# Altium schematic sheet 04 PX4-Connect.SchDoc("04 PX4-Connect")
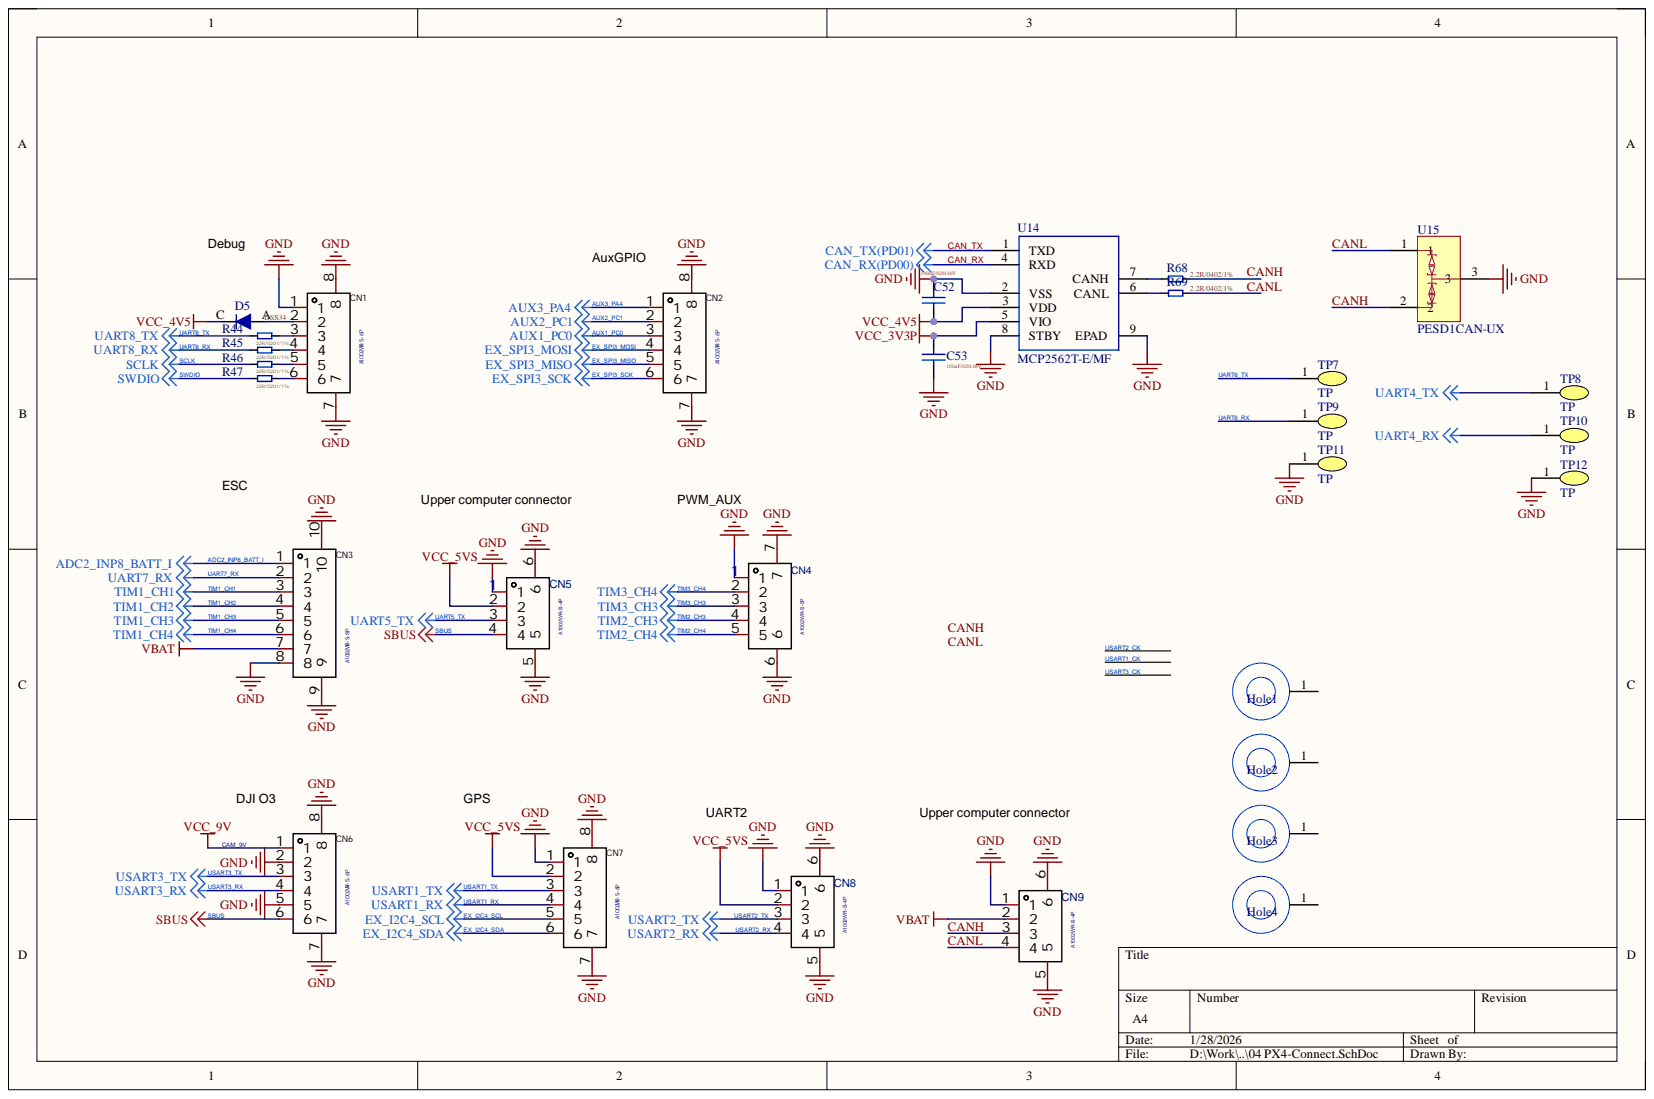
[diagram p0: 04 PX4-Connect.SchDoc]

## Parts
C52  100nF/0201/16V  [C0201]
C53  100nF/0201/16V  [C0201]
CN1  A1002WR-S-6P  [CONN-SMD_A1002WR-S-6P]
CN2  A1002WR-S-6P  [CONN-SMD_A1002WR-S-6P]
CN3  A1002WR-S-8P  [CONN-SMD_A1002WR-S-8P]
CN4  A1002WR-S-5P  [CONN-SMD_A1002WR-S-5P]
CN5  A1002WR-S-4P  [CONN-SMD_A1002WR-S-4P]
CN6  A1002WR-S-6P  [CONN-SMD_A1002WR-S-6P]
CN7  A1002WR-S-6P  [CONN-SMD_A1002WR-S-6P]
CN8  A1002WR-S-4P  [CONN-SMD_A1002WR-S-4P]
CN9  A1002WR-S-4P  [CONN-SMD_A1002WR-S-4P]
D5  DSS34  [SOD-123FL_L2.8-W1.8-LS3.7-AC]
Hole1  Hole  [HOLE_PC3P4DC3P05]
Hole2  Hole  [HOLE_PC3P4DC3P05]
Hole3  Hole  [HOLE_PC3P4DC3P05]
Hole4  Hole  [HOLE_PC3P4DC3P05]
R44  22R/0201/1%  [R0201]
R45  22R/0201/1%  [R0201]
R46  22R/0201/1%  [R0201]
R47  22R/0201/1%  [R0201]
R68  2.2R/0402/1%  [R0402]
R69  2.2R/0402/1%  [R0402]
TP7  TP  [PAD1x1.2]
TP8  TP  [PAD1x1.2]
TP9  TP  [PAD1x1.2]
TP10  TP  [PAD1x1.2]
TP11  TP  [PAD1x1.2]
TP12  TP  [PAD1x1.2]
U14  MCP2562T-E/MF  [DFN-8_EP_3X3MM-0.65]
U15  PESD1CAN-UX  [SOT-323_L2.0-W1.3-P1.30-LS2.1-BR]

## Nets
GND: C52.1 C53.1 CN1.1 CN1.7 CN1.8 CN2.7 CN2.8 CN3.8 CN3.9 CN3.10 CN4.1 CN4.6 CN4.7 CN5.1 CN5.5 CN5.6 CN6.2 CN6.5 CN6.7 CN6.8 CN7.1 CN7.7 CN7.8 CN8.1 CN8.5 CN8.6 CN9.1 CN9.5 CN9.6 TP11.1 TP12.1 U14.2(VSS) U14.8(STBY) U14.9(EPAD) U15.3
UART4_RX: TP10.1
UART4_TX: TP8.1
VBAT: CN3.7 CN9.2
VCC_3V3P: C53.2 U14.5(VIO)
VCC_4V5: C52.2 D5.C U14.3(VDD)
VCC_5VS: CN5.2 CN7.2 CN8.2
ADC2_INP8_BATT_I: CN3.1
AUX1_PC0: CN2.3
AUX2_PC1: CN2.2
AUX3_PA4: CN2.1
CAM_9V: CN6.1
CAN_RX: U14.4(RXD)
CAN_TX: U14.1(TXD)
CANH: CN9.3 R68.1 U15.2
CANL: CN9.4 R69.1 U15.1
EX_I2C4_SCL: CN7.5
EX_I2C4_SDA: CN7.6
EX_SPI3_MISO: CN2.5
EX_SPI3_MOSI: CN2.4
EX_SPI3_SCK: CN2.6
SBUS: CN5.4 CN6.6
SCLK: R46.2
SWDIO: R47.2
TIM1_CH1: CN3.3
TIM1_CH2: CN3.4
TIM1_CH3: CN3.5
TIM1_CH4: CN3.6
TIM2_CH3: CN4.4
TIM2_CH4: CN4.5
TIM3_CH3: CN4.3
TIM3_CH4: CN4.2
UART5_TX: CN5.3
UART6_RX: TP9.1
UART6_TX: TP7.1
UART7_RX: CN3.2
UART8_RX: R45.2
UART8_TX: R44.2
USART1_RX: CN7.4
USART1_TX: CN7.3
USART2_RX: CN8.4
USART2_TX: CN8.3
USART3_RX: CN6.4
USART3_TX: CN6.3
NetCN1_2: CN1.2 D5.A
NetCN1_3: CN1.3 R44.1
NetCN1_4: CN1.4 R45.1
NetCN1_5: CN1.5 R46.1
NetCN1_6: CN1.6 R47.1
NetR68_2: R68.2 U14.7(CANH)
NetR69_2: R69.2 U14.6(CANL)
USART1_CK:
USART2_CK:
USART3_CK:

Not connected: Hole1 1; Hole2 1; Hole3 1; Hole4 1

## Library data of the parts
C52 C53 = Cap: Value: 100nF/0603/50V; Component Kind: Standard; Description: 100nF/0201/16V; LatestRevisionDate: 17-Jul-2002; LatestRevisionNote: Re-released for DXP Platform.; Library Name: Miscellaneous Devices.IntLib; PackageDescription: Radial Cap, Thru-Hole; 2 Leads; 0.3 in Pin Spacing; PackageReference: RAD-0.3; Published: 8-Jun-2000; Signal Integrity: Cap; Simulation: CAP; Publisher: Altium Limited
CN1 CN2 CN6 CN7 = A1002WR-S-6P: Manufacturer: CJT(长江连接器); Add into BOM: yes; Connector Type: 接头; Device: A1002WR-S-8P; Number of Positions: 8; Pitch: 0.039"(1.00mm); Component Kind: Standard; Contact Material: 磷青铜; Contact Type: 公形引脚; Convert to PCB: yes; Datasheet: https://atta.szlcsc.com/upload/public/pdf/watermark/20190926/C239526_E6EB898D74B3D7AFB647860A542281E4.pdf; JLCPCB Part Class: Extended Part; LCSC Part Name: 8P,脚距1mm; Library Name: dm-sch_library.SchLib; Manufacturer Part: A1002WR-S-8P; Mounting Type: 表面贴装; Name: A1002WR-S-8P; Number of Rows: 1; PCB3D: CONN-SMD_SH1.00-WS-8P; Row Spacing: -; Series: SH; Supplier: LCSC; Supplier Footprint: SMD,P=1mm; Supplier Part: C239526; Symbol: A1002WR-S-8P
CN3 = A1002WR-S-8P: Manufacturer: CJT(长江连接器); Add into BOM: yes; Connector Type: 接头; Number of Positions: 8; Pitch: 0.039"(1.00mm); Component Kind: Standard; Contact Material: 磷青铜; Contact Type: 公形引脚; Convert to PCB: yes; Datasheet: https://atta.szlcsc.com/upload/public/pdf/watermark/20190926/C239526_E6EB898D74B3D7AFB647860A542281E4.pdf; Device: A1002WR-S-8P; JLCPCB Part Class: Extended Part; LCSC Part Name: 8P,脚距1mm; Library Name: dm-sch_library.SchLib; Manufacturer Part: A1002WR-S-8P; Mounting Type: 表面贴装; Name: A1002WR-S-8P; Number of Rows: 1; PCB3D: CONN-SMD_SH1.00-WS-8P; Row Spacing: -; Series: SH; Supplier: LCSC; Supplier Footprint: SMD,P=1mm; Supplier Part: C239526; Symbol: A1002WR-S-8P
CN4 = A1002WR-S-5P: BOM_Manufacturer: Changjiang Connectors; Contributor: LCSC; BOM_Manufacturer Part: A1002WR-S-5P; BOM_Supplier: LCSC; BOM_Supplier Part: C239523; Component Kind: Standard; Library Name: dm-sch_library.SchLib; spicePre: C; spiceSymbolName: A1002WR-S-5P
CN5 CN8 CN9 = A1002WR-S-4P: BOM_Manufacturer: Changjiang Connectors; Contributor: LCSC; BOM_Manufacturer Part: A1002WR-S-4P; BOM_Supplier: LCSC; BOM_Supplier Part: C239522; Component Kind: Standard; Library Name: dm-sch_library.SchLib; spicePre: C; spiceSymbolName: A1002WR-S-4P
D5 = DIODE: Component Kind: Standard; Description: DSS34; Library Name: ROBO_V01_2015.SchLib
R44-R47 R68 R69 = R: Component Kind: Standard; Library Name: ROBO_V01_2015.SchLib
  R44-R47: Description: 22R/0201/1%
  R68 R69: Description: 2.2R/0402/1%
TP7-TP12 = TP: TP,1mmx1mm; Component Kind: Standard; Library Name: ROBO_V01_2015-DESKTOP-643COGG.SchLib
U14 = MCP2542FDT: ?MCP2542FDT-H/MNY?; Component Kind: Standard; Library Name: ROBO_V01_2015.SchLib
U15 = PESD1CAN: Component Kind: Standard; Description: PESD1CAN-UX; Library Name: Schlib1.SchLib
Hole1-Hole4 = Hole: Component Kind: Standard; Library Name: ROBO_V01_2015.SchLib
